# Altium schematic sheet MAIN.SchDoc(MAIN)
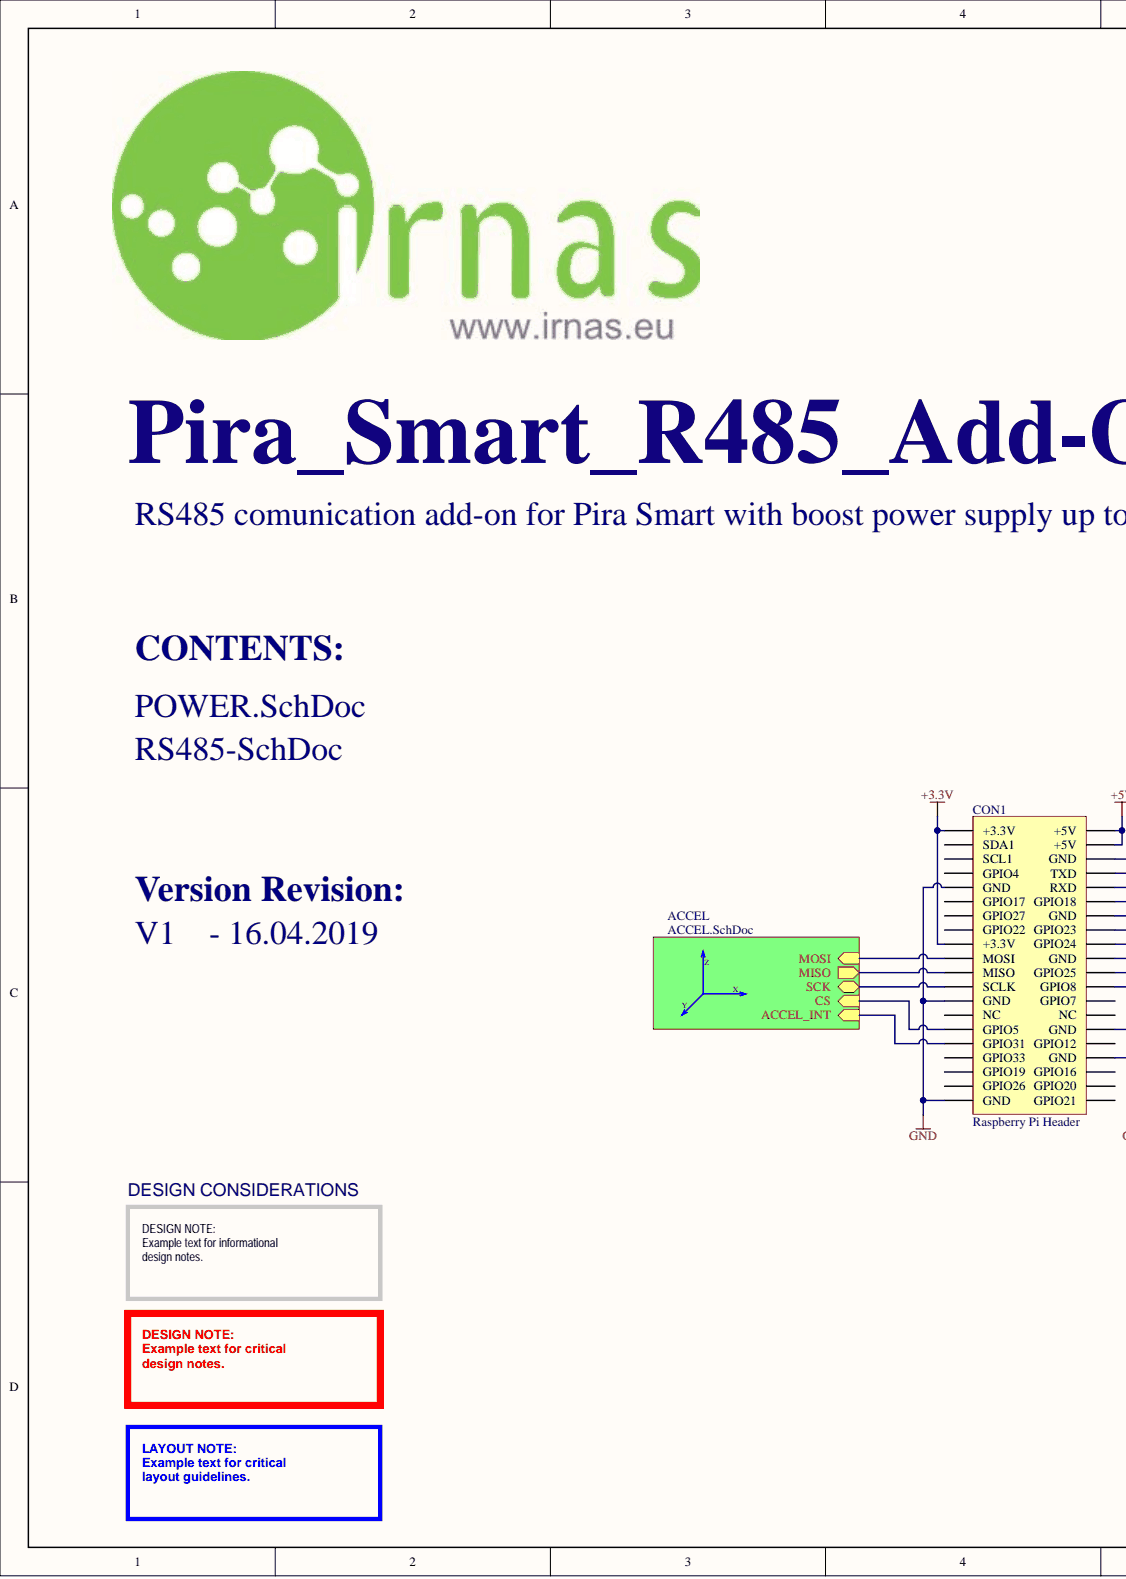
[diagram p0: MAIN.SchDoc, left]
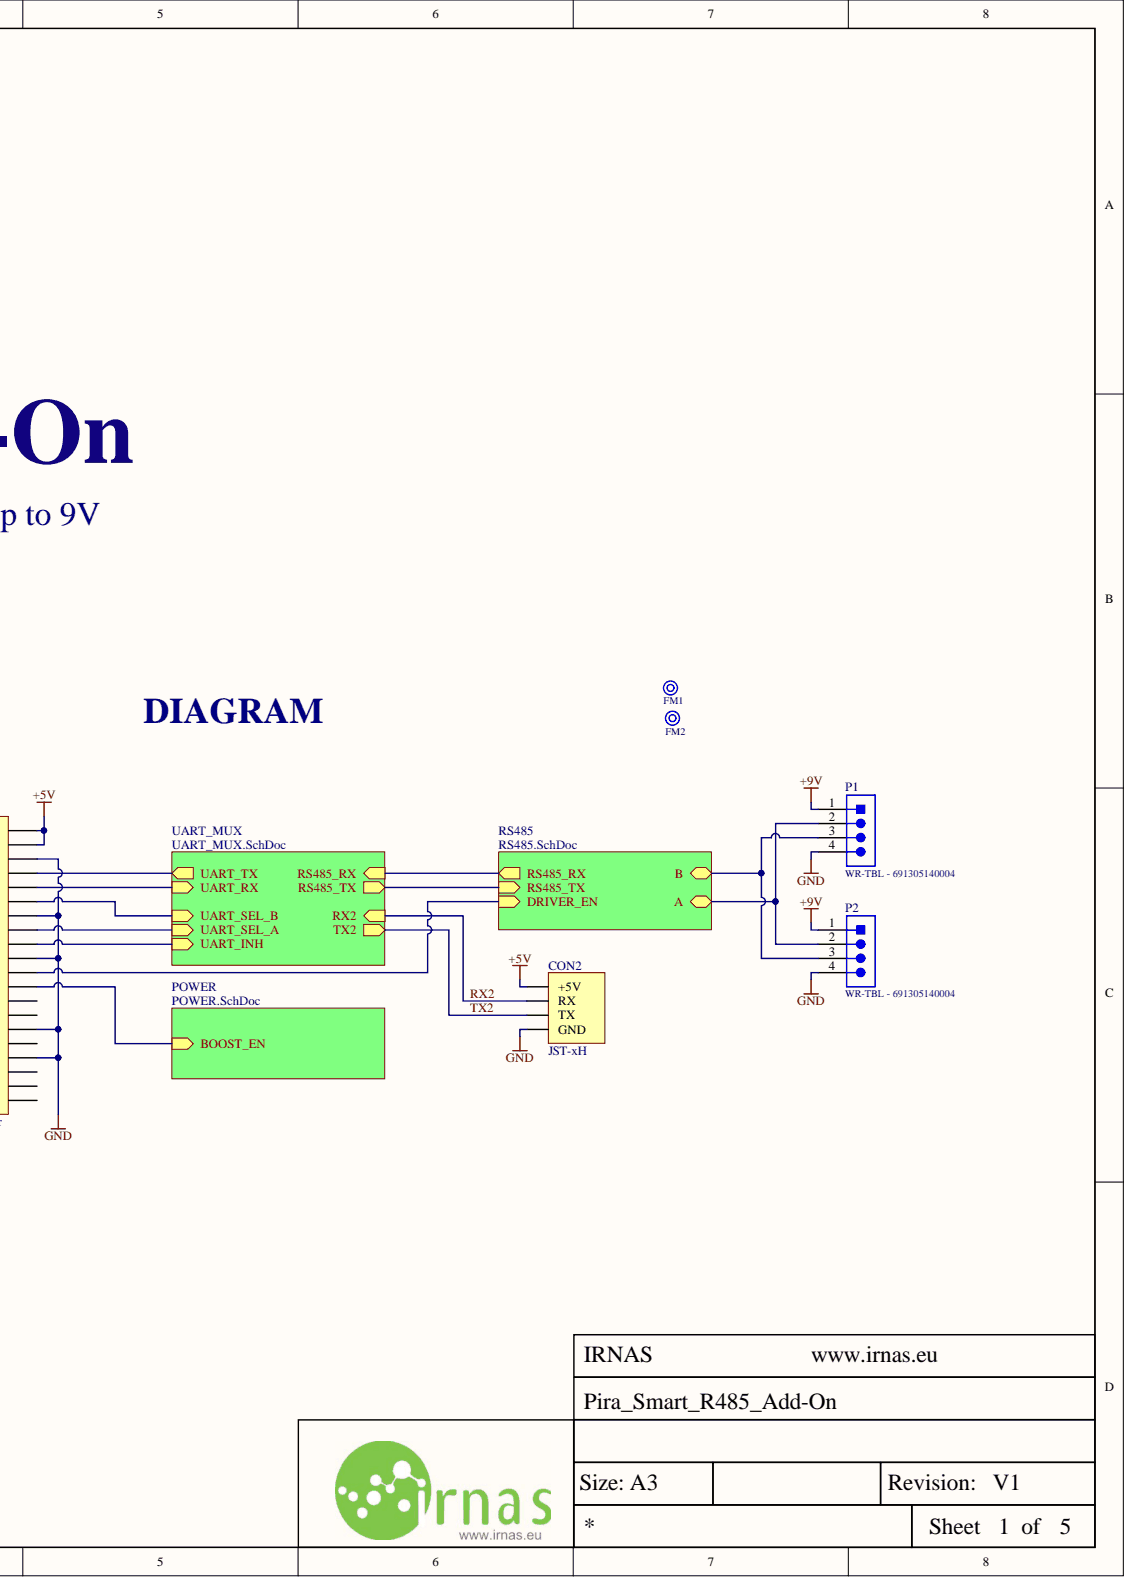
[diagram p1: MAIN.SchDoc, right]

## Parts
CON1  Raspberry Pi Header  [HDR2X20]
CON2  JST-xH  [JST-xH]
FM1  FIDUCAL MARKER  [FIDUCAL]  (value not drawn)
FM2  FIDUCAL MARKER  [FIDUCAL]  (value not drawn)
P1  WR-TBL - 691305140004  [691305140004]
P2  WR-TBL - 691305140004  [691305140004]

## Nets
+3.3V: CON1.1(+3.3V) CON1.17(+3.3V)
+5V: CON1.2(+5V) CON1.4(+5V) CON2.1(+5V)
+9V: P1.1 P2.1
GND: CON1.6(GND) CON1.9(GND) CON1.14(GND) CON1.20(GND) CON1.25(GND) CON1.30(GND) CON1.34(GND) CON1.39(GND) CON2.4(GND) P1.4 P2.4
RX2: CON2.2(RX)
TX2: CON2.3(TX)
NetCON1_3: CON1.3(SDA1)
NetCON1_5: CON1.5(SCL1)
NetCON1_7: CON1.7(GPIO4)
NetCON1_8: CON1.8(TXD)
NetCON1_10: CON1.10(RXD)
NetCON1_11: CON1.11(GPIO17)
NetCON1_12: CON1.12(GPIO18)
NetCON1_13: CON1.13(GPIO27)
NetCON1_15: CON1.15(GPIO22)
NetCON1_16: CON1.16(GPIO23)
NetCON1_18: CON1.18(GPIO24)
NetCON1_19: CON1.19(MOSI)
NetCON1_21: CON1.21(MISO)
NetCON1_22: CON1.22(GPIO25)
NetCON1_23: CON1.23(SCLK)
NetCON1_24: CON1.24(GPIO8)
NetCON1_26: CON1.26(GPIO7)
NetCON1_27: CON1.27(NC)
NetCON1_28: CON1.28(NC)
NetCON1_29: CON1.29(GPIO5)
NetCON1_31: CON1.31(GPIO31)
NetCON1_32: CON1.32(GPIO12)
NetCON1_33: CON1.33(GPIO33)
NetCON1_35: CON1.35(GPIO19)
NetCON1_36: CON1.36(GPIO16)
NetCON1_37: CON1.37(GPIO26)
NetCON1_38: CON1.38(GPIO20)
NetCON1_40: CON1.40(GPIO21)
NetP1_2: P1.2 P2.2
NetP1_3: P1.3 P2.3
RS485_RX:
RS485_TX:

## Library data of the parts
CON1 = Raspberry Pi Header: Header, 20-Pin, Dual row; Library Name: Connectors.SchLib; LatestRevisionNote: Re-released for DXP Platform.; LatestRevisionDate: 17-Jul-2002; Component Kind: Standard; Publisher: Altium Limited
CON2 = B4B-XH-A(LF)(SN): 4 Positions Header, Shrouded Connector 0.098" (2.50mm) Through Hole Tin; Manufacturer: JST; Voltage Rating: 250 V; Style/Orientation: Straight Header/Plug; Pitch: 2.5 mm; No of Rows: 1; No of Positions: 4; Mating Type: Detent Lock; Insulation Resistance: 1000 MΩ; Gender: Male; Current Rating: 3 A; Type: Shrouded Header; Termination: Crimp; Supplier Part Number 1: 1516278; Supplier 1: Farnell; Stock 1: 0; Operating Temp Range: -25 to +85 °C; Material: Polyamide; Manufacturer Part Number: B4B-XH-A(LF)(SN); Library Name: Connectors.SchLib; Contact Plating: Tin; Contact Material: Brass; ComponentLink4URL: https://datasheet.ciiva.com/726/exh-726404.pdf; ComponentLink4Description: https://datasheet.ciiva.com/726/exh-726404.pdf; ComponentLink3URL: https://datasheet.ciiva.com/16203/b4b-xh-alfsn-16203642.pdf; ComponentLink3Description: https://datasheet.ciiva.com/16203/b4b-xh-alfsn-16203642.pdf; ComponentLink2URL: https://datasheet.ciiva.com/26924/getdatasheetpartid-640571-26924035.pdf; ComponentLink2Description: https://datasheet.ciiva.com/26924/getdatasheetpartid-640571-26924035.pdf; ComponentLink1URL: https://datasheet.ciiva.com/26894/getdatasheetpartid-455616-26894787.pdf; ComponentLink1Description: https://datasheet.ciiva.com/26894/getdatasheetpartid-455616-26894787.pdf; Component Kind: Standard; Color: Natural
FM1 FM2 = FIDUCAL: Komp / Device: SMD; FIT: 0.94; Library Name: Mechanical.SchLib; Description: FIDUCAL MARKER; ComponentLink1Description: Datasheet; Component Kind: Standard
P1 P2 = WR-TBL - 691305140004: Serie 3051 - 3.50mm Horizontal PCB Header with Plastic Latch WR-TBL, 4 pin; Pin Number: 4; Gender: Male; Published Date: June 2018; Pitch: 3.50 mm; Part Number: 691305140004; Packaging: Box; Operating Temperature: -40 to 105 degC; Mounting Technology: THT; Library Name: Connectors.SchLib; ComponentLink2URL: http://katalog.we-online.de/em/datasheet/691305140004.pdf; ComponentLink2Description: Datasheet; ComponentLink1URL: http://www.we-online.de; ComponentLink1Description: Manufacturer; Component Kind: Standard; Publisher: Wurth Elektronik
